# Altium schematic sheet [23] - DDR4 MEMORY PS I.SchDoc("[23] - DDR4 MEMORY PS I")
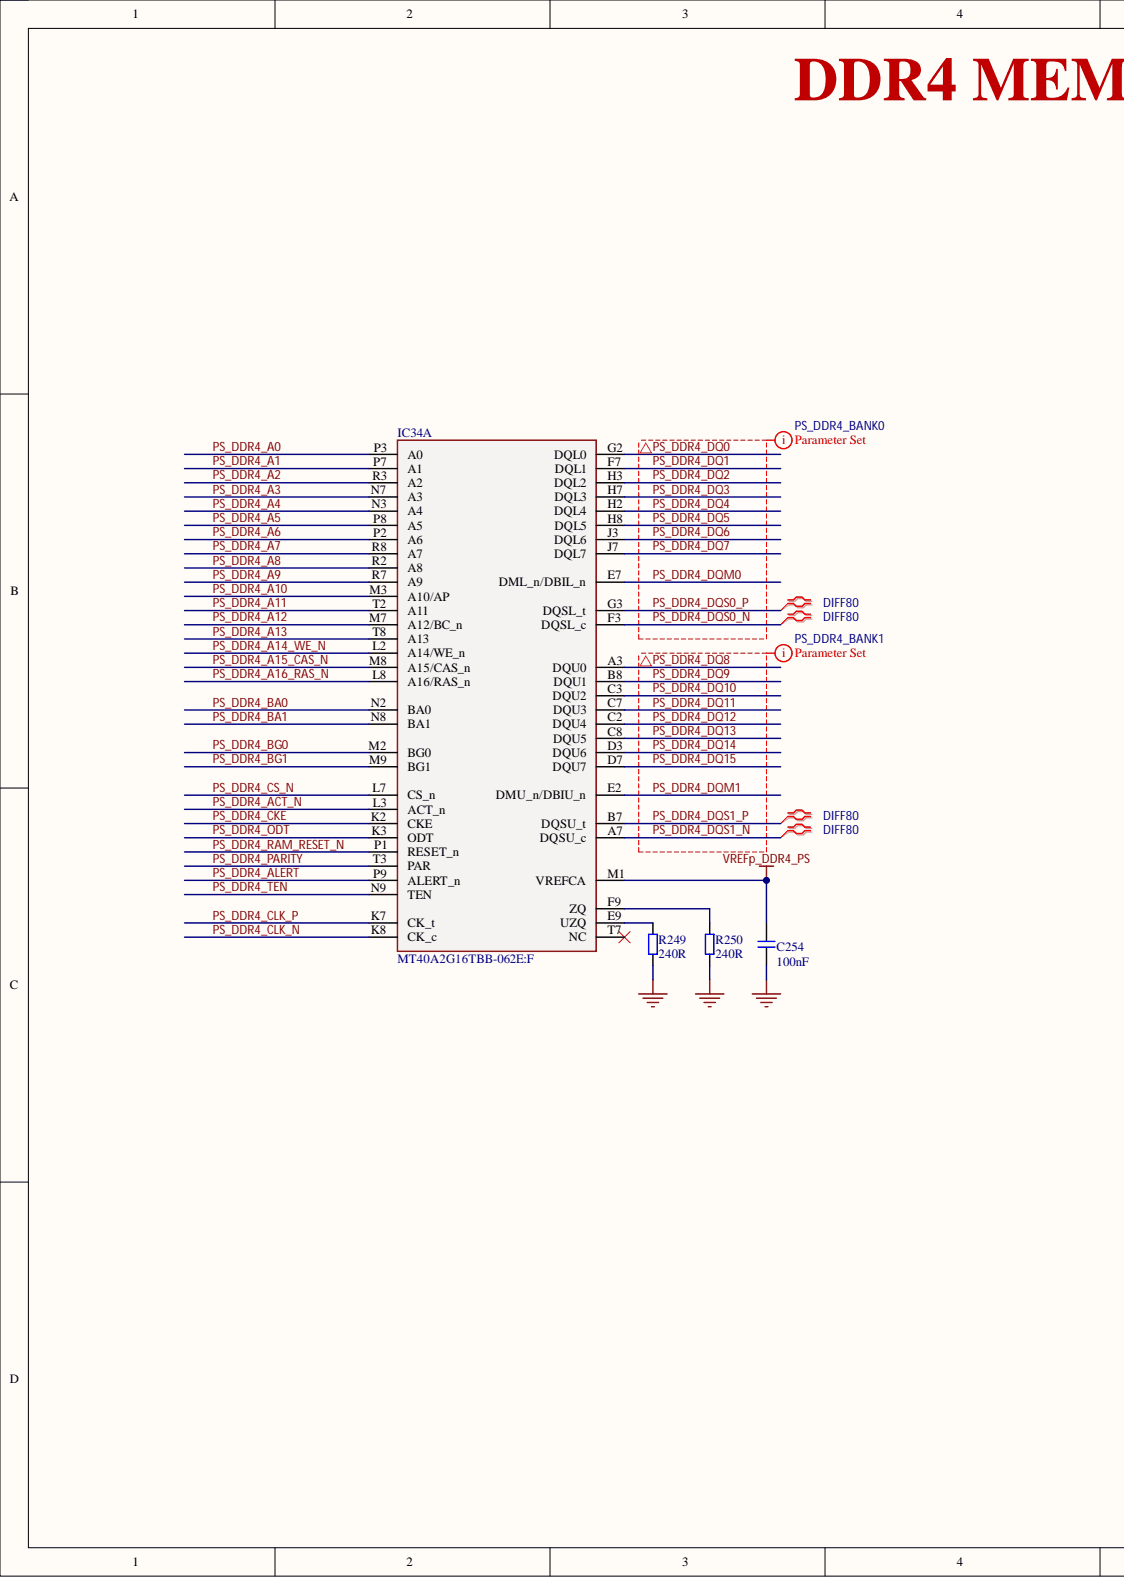
[diagram p0: [23] - DDR4 MEMORY PS I.SchDoc, left]
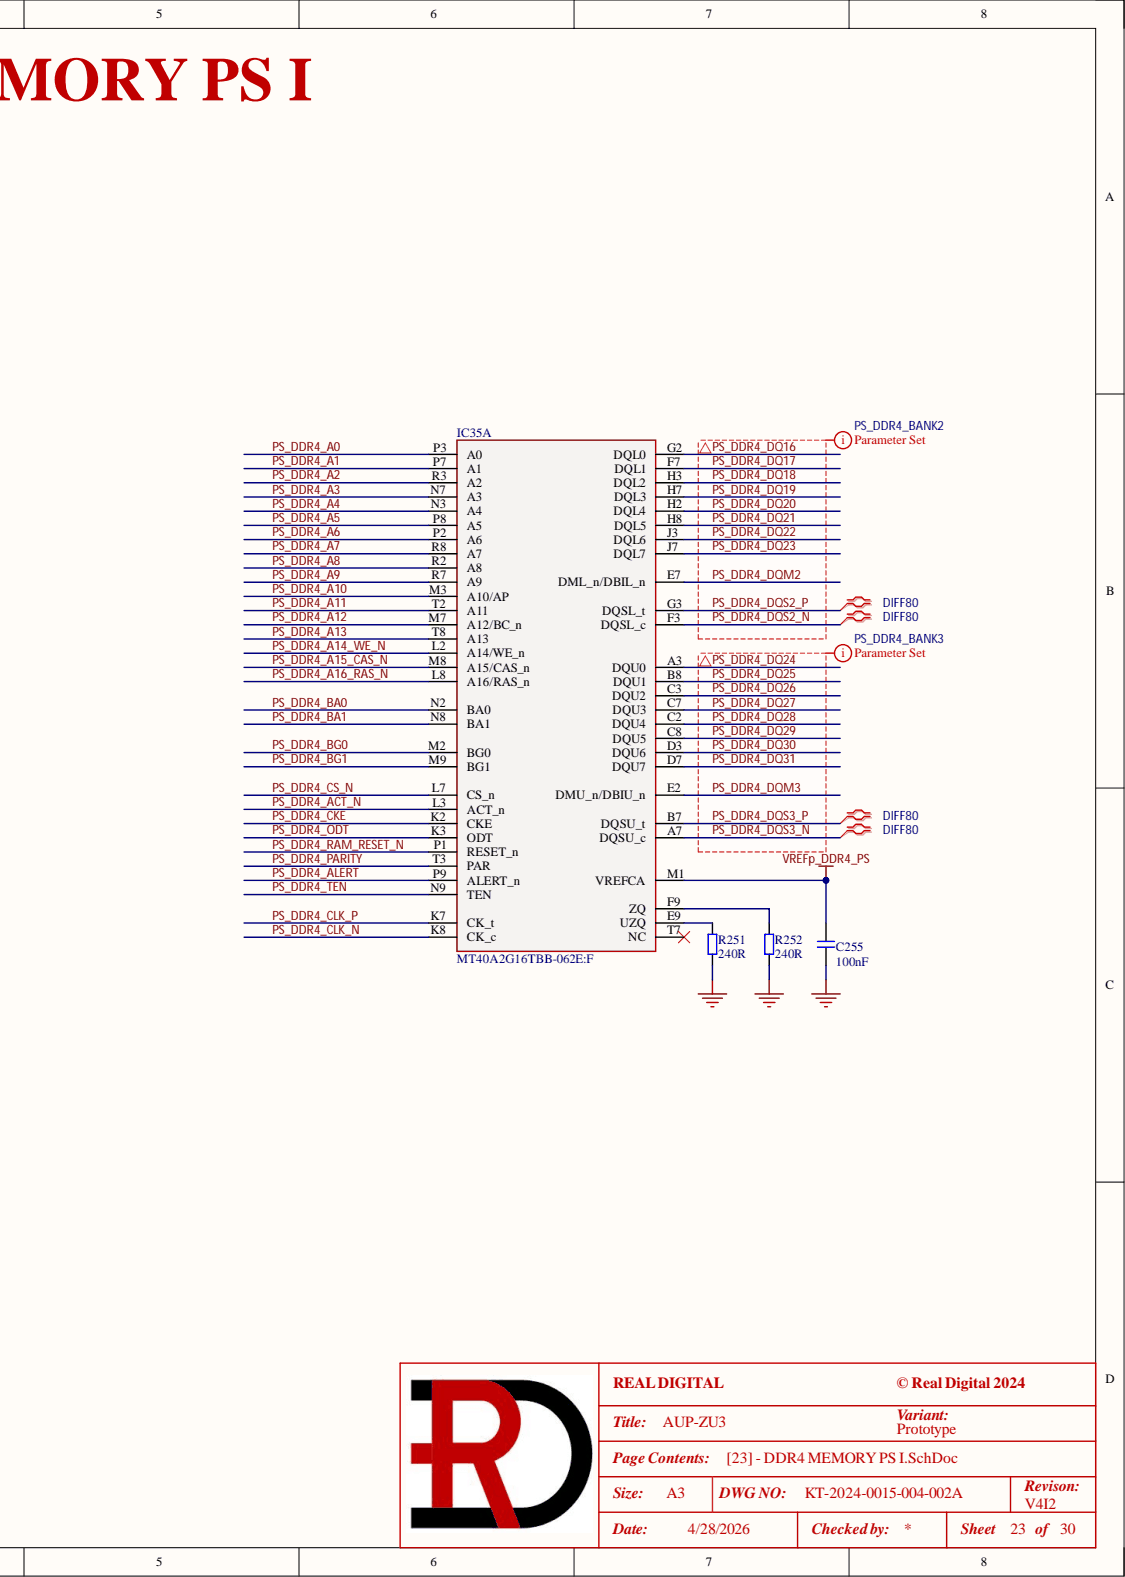
[diagram p1: [23] - DDR4 MEMORY PS I.SchDoc, right]

## Parts
C254  100nF  [C0402]
C255  100nF  [C0402]
IC34  MT40A2G16TBB-062E:F  [FBGA96_8x14mm - MICRON]  (part A)
IC35  MT40A2G16TBB-062E:F  [FBGA96_8x14mm - MICRON]  (part A)
R249  240R  [R0402]
R250  240R  [R0402]
R251  240R  [R0402]
R252  240R  [R0402]

## Nets
GND: C254.1 C255.1 R249.1 R250.1 R251.1 R252.1
VREFp_DDR4_PS: C254.2 C255.2 IC34.M1(VREFCA) IC35.M1(VREFCA)
PS_DDR4_A0: IC34.P3(A0) IC35.P3(A0)
PS_DDR4_A1: IC34.P7(A1) IC35.P7(A1)
PS_DDR4_A2: IC34.R3(A2) IC35.R3(A2)
PS_DDR4_A3: IC34.N7(A3) IC35.N7(A3)
PS_DDR4_A4: IC34.N3(A4) IC35.N3(A4)
PS_DDR4_A5: IC34.P8(A5) IC35.P8(A5)
PS_DDR4_A6: IC34.P2(A6) IC35.P2(A6)
PS_DDR4_A7: IC34.R8(A7) IC35.R8(A7)
PS_DDR4_A8: IC34.R2(A8) IC35.R2(A8)
PS_DDR4_A9: IC34.R7(A9) IC35.R7(A9)
PS_DDR4_A10: IC34.M3(A10/AP) IC35.M3(A10/AP)
PS_DDR4_A11: IC34.T2(A11) IC35.T2(A11)
PS_DDR4_A12: IC34.M7(A12/BC_n) IC35.M7(A12/BC_n)
PS_DDR4_A13: IC34.T8(A13) IC35.T8(A13)
PS_DDR4_A14_WE_N: IC34.L2(A14/WE_n) IC35.L2(A14/WE_n)
PS_DDR4_A15_CAS_N: IC34.M8(A15/CAS_n) IC35.M8(A15/CAS_n)
PS_DDR4_A16_RAS_N: IC34.L8(A16/RAS_n) IC35.L8(A16/RAS_n)
PS_DDR4_ACT_N: IC34.L3(ACT_n) IC35.L3(ACT_n)
PS_DDR4_ALERT: IC34.P9(ALERT_n) IC35.P9(ALERT_n)
PS_DDR4_BA0: IC34.N2(BA0) IC35.N2(BA0)
PS_DDR4_BA1: IC34.N8(BA1) IC35.N8(BA1)
PS_DDR4_BG0: IC34.M2(BG0) IC35.M2(BG0)
PS_DDR4_BG1: IC34.M9(BG1) IC35.M9(BG1)
PS_DDR4_CKE: IC34.K2(CKE) IC35.K2(CKE)
PS_DDR4_CLK_N: IC34.K8(CK_c) IC35.K8(CK_c)
PS_DDR4_CLK_P: IC34.K7(CK_t) IC35.K7(CK_t)
PS_DDR4_CS_N: IC34.L7(CS_n) IC35.L7(CS_n)
PS_DDR4_DQ0: IC34.G2(DQL0)
PS_DDR4_DQ1: IC34.F7(DQL1)
PS_DDR4_DQ2: IC34.H3(DQL2)
PS_DDR4_DQ3: IC34.H7(DQL3)
PS_DDR4_DQ4: IC34.H2(DQL4)
PS_DDR4_DQ5: IC34.H8(DQL5)
PS_DDR4_DQ6: IC34.J3(DQL6)
PS_DDR4_DQ7: IC34.J7(DQL7)
PS_DDR4_DQ8: IC34.A3(DQU0)
PS_DDR4_DQ9: IC34.B8(DQU1)
PS_DDR4_DQ10: IC34.C3(DQU2)
PS_DDR4_DQ11: IC34.C7(DQU3)
PS_DDR4_DQ12: IC34.C2(DQU4)
PS_DDR4_DQ13: IC34.C8(DQU5)
PS_DDR4_DQ14: IC34.D3(DQU6)
PS_DDR4_DQ15: IC34.D7(DQU7)
PS_DDR4_DQ16: IC35.G2(DQL0)
PS_DDR4_DQ17: IC35.F7(DQL1)
PS_DDR4_DQ18: IC35.H3(DQL2)
PS_DDR4_DQ19: IC35.H7(DQL3)
PS_DDR4_DQ20: IC35.H2(DQL4)
PS_DDR4_DQ21: IC35.H8(DQL5)
PS_DDR4_DQ22: IC35.J3(DQL6)
PS_DDR4_DQ23: IC35.J7(DQL7)
PS_DDR4_DQ24: IC35.A3(DQU0)
PS_DDR4_DQ25: IC35.B8(DQU1)
PS_DDR4_DQ26: IC35.C3(DQU2)
PS_DDR4_DQ27: IC35.C7(DQU3)
PS_DDR4_DQ28: IC35.C2(DQU4)
PS_DDR4_DQ29: IC35.C8(DQU5)
PS_DDR4_DQ30: IC35.D3(DQU6)
PS_DDR4_DQ31: IC35.D7(DQU7)
PS_DDR4_DQM0: IC34.E7(DML_n/DBIL_n)
PS_DDR4_DQM1: IC34.E2(DMU_n/DBIU_n)
PS_DDR4_DQM2: IC35.E7(DML_n/DBIL_n)
PS_DDR4_DQM3: IC35.E2(DMU_n/DBIU_n)
PS_DDR4_DQS0_N: IC34.F3(DQSL_c)
PS_DDR4_DQS0_P: IC34.G3(DQSL_t)
PS_DDR4_DQS1_N: IC34.A7(DQSU_c)
PS_DDR4_DQS1_P: IC34.B7(DQSU_t)
PS_DDR4_DQS2_N: IC35.F3(DQSL_c)
PS_DDR4_DQS2_P: IC35.G3(DQSL_t)
PS_DDR4_DQS3_N: IC35.A7(DQSU_c)
PS_DDR4_DQS3_P: IC35.B7(DQSU_t)
PS_DDR4_ODT: IC34.K3(ODT) IC35.K3(ODT)
PS_DDR4_PARITY: IC34.T3(PAR) IC35.T3(PAR)
PS_DDR4_RAM_RESET_N: IC34.P1(RESET_n) IC35.P1(RESET_n)
PS_DDR4_TEN: IC34.N9(TEN) IC35.N9(TEN)
NetIC34_E9: IC34.E9(UZQ) R249.2
NetIC34_F9: IC34.F9(ZQ) R250.2
NetIC35_E9: IC35.E9(UZQ) R251.2
NetIC35_F9: IC35.F9(ZQ) R252.2

Not connected: IC34 T7(NC); IC35 T7(NC)

## Library data of the parts
C254 C255 = GRM155R71E104KE14J: CAP CER 0.1uF 25V X7R 0402; Manufacturer 1: Murata Electronics; .Checked_PCB: AC; .Creator: RV; C P/N: RD-111-0006; LoadStatus: Load; Tolerance: 10%; Category: Capacitors; Component Kind: Standard; Library Name: KT-RealDigital.IntLib; Manufacturer 1 Part Number: GRM155R71E104KE14J; Temperature Coefficient: X7R; Voltage - Rated: 25V; .Checked_SCH: AC
IC34 IC35 = MT40A2G16TBB-062E:F: IC DRAM 32GBIT PARALLEL 96FBGA (TwinDie); Manufacturer 1: Micron Technology Inc.; .Checked_PCB: RV; .Creator: DG; C P/N: RD-126-1528; LoadStatus: Load; Category: IC; Component Kind: Standard; Library Name: KT-RealDigital.IntLib; Manufacturer 1 Part Number: MT40A2G16TBB-062E:F; .Checked_SCH: RV
R249-R252 = RC0402FR-07240RL: RES SMD 240 OHM 1% 1/16W 0402; Manufacturer 1: Yageo; .Checked_PCB: AC; .Creator: RV; C P/N: RD-101-0037; LoadStatus: Load; Tolerance: 1%/TK100; Category: Resistors; Component Kind: Standard; Library Name: KT-RealDigital.IntLib; Manufacturer 1 Part Number: RC0402FR-07240RL; .Checked_SCH: AC
